# Altium schematic sheet preamp_v1r4.SchDoc
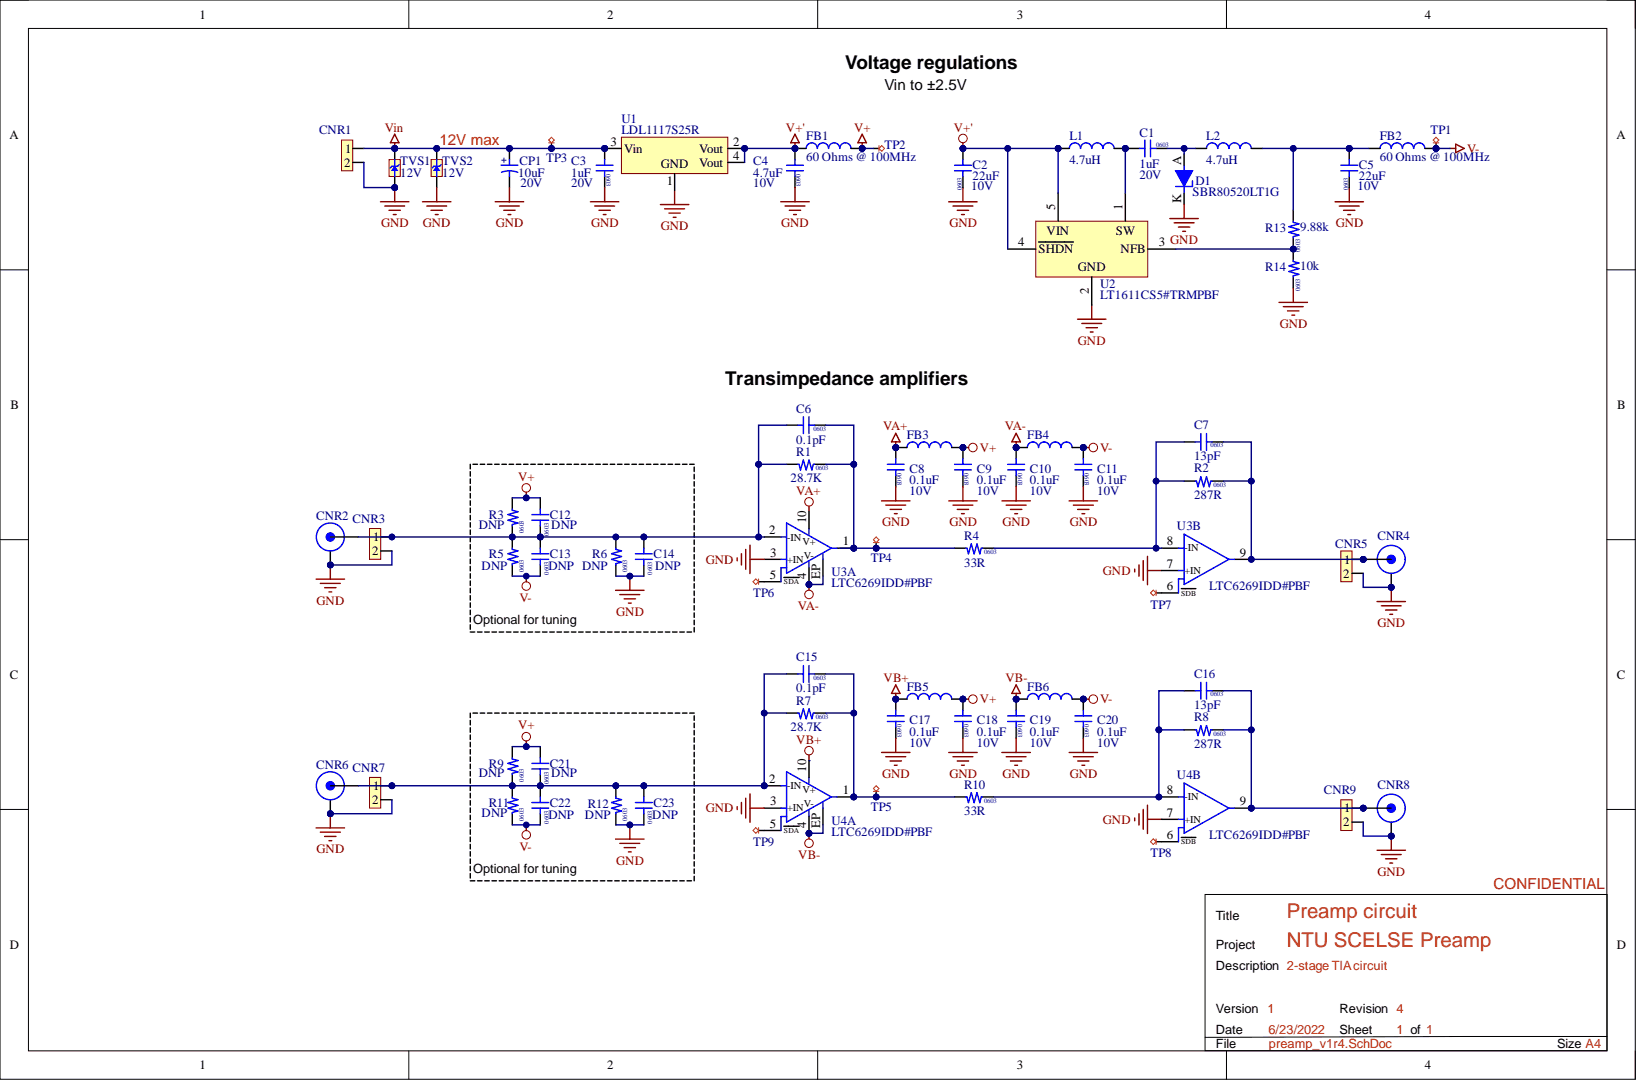
[diagram p0: preamp_v1r4.SchDoc]

## Parts
C1  value 1uF  PCB Footprint: 0603  [RES-0603]
C2  value 22uF  PCB Footprint: 0603  Rating: 10V  [CAP-0603]  (hidden comment: - CAP-NON)
C3  value 1uF  PCB Footprint: 0603  Rating: 20V  [RES-0603]
C4  value 4.7uF  PCB Footprint: 0603  Rating: 10V  [RES-0603]
C5  value 22uF  PCB Footprint: 0603  Rating: 10V  [CAP-0603]  (hidden comment: - CAP-NON)
C6  value 0.1pF  PCB Footprint: 0603  [CAP-0603]  (hidden comment: - CAP-NON)
C7  value 13pF  PCB Footprint: 0603  [CAP-0603]  (hidden comment: - CAP-NON)
C8  value 0.1uF  PCB Footprint: 0603  Rating: 10V  [RES-0603]
C9  value 0.1uF  PCB Footprint: 0603  Rating: 10V  [RES-0603]
C10  value 0.1uF  PCB Footprint: 0603  Rating: 10V  [RES-0603]
C11  value 0.1uF  PCB Footprint: 0603  Rating: 10V  [RES-0603]
C12  Cmmt: DNP  PCB Footprint: 0603  [CAP-0603]  (hidden comment: - CAP-NON)
C13  Cmmt: DNP  PCB Footprint: 0603  [CAP-0603]  (hidden comment: - CAP-NON)
C14  Cmmt: DNP  PCB Footprint: 0603  [CAP-0603]  (hidden comment: - CAP-NON)
C15  value 0.1pF  PCB Footprint: 0603  [CAP-0603]  (hidden comment: - CAP-NON)
C16  value 13pF  PCB Footprint: 0603  [CAP-0603]  (hidden comment: - CAP-NON)
C17  value 0.1uF  PCB Footprint: 0603  Rating: 10V  [RES-0603]
C18  value 0.1uF  PCB Footprint: 0603  Rating: 10V  [RES-0603]
C19  value 0.1uF  PCB Footprint: 0603  Rating: 10V  [RES-0603]
C20  value 0.1uF  PCB Footprint: 0603  Rating: 10V  [RES-0603]
C21  Cmmt: DNP  PCB Footprint: 0603  [CAP-0603]  (hidden comment: - CAP-NON)
C22  Cmmt: DNP  PCB Footprint: 0603  [CAP-0603]  (hidden comment: - CAP-NON)
C23  Cmmt: DNP  PCB Footprint: 0603  [CAP-0603]  (hidden comment: - CAP-NON)
CNR1  - CNR-IDC:2x1  [SIP02-2.54P]  (value not drawn)  (no BOM)
CNR2  SMA  [SMA_EDGE]  (value not drawn)
CNR3  - CNR-IDC:2x1  [SIP02-2.54P]  (value not drawn)  (no BOM)
CNR4  SMA  [SMA_EDGE]  (value not drawn)
CNR5  - CNR-IDC:2x1  [SIP02-2.54P]  (value not drawn)  (no BOM)
CNR6  SMA  [SMA_EDGE]  (value not drawn)
CNR7  - CNR-IDC:2x1  [SIP02-2.54P]  (value not drawn)  (no BOM)
CNR8  SMA  [SMA_EDGE]  (value not drawn)
CNR9  - CNR-IDC:2x1  [SIP02-2.54P]  (value not drawn)  (no BOM)
CP1  value 10uF  Rating: 20V  [SME8x10.5-2.2E [E]]  (hidden comment: - CAP-POL)
D1  Manufacturer Part No: SBR80520LT1G  [SOD123]  (hidden comment: - DIO-ZNR)
FB1  value 60 Ohms @ 100MHz  [IND-0603]  (hidden comment: Ferrite bead)
FB2  value 60 Ohms @ 100MHz  [IND-0603]  (hidden comment: Ferrite bead)
FB3  Ferrite bead  [IND-0603]  (value not drawn)
FB4  Ferrite bead  [IND-0603]  (value not drawn)
FB5  Ferrite bead  [IND-0603]  (value not drawn)
FB6  Ferrite bead  [IND-0603]  (value not drawn)
L1  value 4.7uH  [IND-0805[NL]]  (hidden comment: - IND)
L2  value 4.7uH  [IND-0805[NL]]  (hidden comment: - IND)
R1  value 28.7K  PCB Footprint: 0603  [RES-0603]  (hidden comment: - RES-FIX)
R2  value 287R  PCB Footprint: 0603  [RES-0603]  (hidden comment: - RES-FIX)
R3  Cmmt: DNP  PCB Footprint: 0603  [RES-0603]  (hidden comment: - RES-FIX)
R4  value 33R  PCB Footprint: 0603  [RES-0603]  (hidden comment: - RES-FIX)
R5  Cmmt: DNP  PCB Footprint: 0603  [RES-0603]  (hidden comment: - RES-FIX)
R6  Cmmt: DNP  PCB Footprint: 0603  [RES-0603]  (hidden comment: - RES-FIX)
R7  value 28.7K  PCB Footprint: 0603  [RES-0603]  (hidden comment: - RES-FIX)
R8  value 287R  PCB Footprint: 0603  [RES-0603]  (hidden comment: - RES-FIX)
R9  Cmmt: DNP  PCB Footprint: 0603  [RES-0603]  (hidden comment: - RES-FIX)
R10  value 33R  PCB Footprint: 0603  [RES-0603]  (hidden comment: - RES-FIX)
R11  Cmmt: DNP  PCB Footprint: 0603  [RES-0603]  (hidden comment: - RES-FIX)
R12  Cmmt: DNP  PCB Footprint: 0603  [RES-0603]  (hidden comment: - RES-FIX)
R13  value 9.88k  PCB Footprint: 0603  [RES-0603]  (hidden comment: - RES-FIX)
R14  value 10k  PCB Footprint: 0603  [RES-0603]  (hidden comment: - RES-FIX)
TP1  * Test Point  [TP-TH]  (value not drawn)  (no BOM)
TP2  * Test Point  [TP-TH]  (value not drawn)  (no BOM)
TP3  * Test Point  [TP-TH]  (value not drawn)  (no BOM)
TP4  * Test Point  [TP-TH]  (value not drawn)  (no BOM)
TP5  * Test Point  [TP-TH]  (value not drawn)  (no BOM)
TP6  * Test Point  [TP-SMD[NL]]  (value not drawn)  (no BOM)
TP7  * Test Point  [TP-SMD[NL]]  (value not drawn)  (no BOM)
TP8  * Test Point  [TP-SMD[NL]]  (value not drawn)  (no BOM)
TP9  * Test Point  [TP-SMD[NL]]  (value not drawn)  (no BOM)
TVS1  value 12V  [SOD923F]  (hidden comment: - TVS-UNI)
TVS2  value 12V  [SOD923F]  (hidden comment: - TVS-UNI)
U1  Manufacturer Part No: LDL1117S25R  [SOT223]  (hidden comment: > ICS: LDL1117SxxR)
U2  Manufacturer Part No: LT1611CS5#TRMPBF  [SOT95P280X145-5N]  (hidden comment: REG: LT1611CS5#TRMPBF)
U3  Manufacturer Part No: LTC6269IDD#PBF  [SON50P300X300X80-11N-D]  (hidden comment: > ICS: LTC6269IDD#PBF)  (parts A,B)
U4  Manufacturer Part No: LTC6269IDD#PBF  [SON50P300X300X80-11N-D]  (hidden comment: > ICS: LTC6269IDD#PBF)  (parts A,B)

## Nets
GND: C2.1 C3.1 C4.1 C5.1 C8.1 C9.1 C10.1 C11.1 C14.1 C17.1 C18.1 C19.1 C20.1 C23.1 CNR1.2 CNR2.2 CNR3.2 CNR4.2 CNR5.2 CNR6.2 CNR7.2 CNR8.2 CNR9.2 CP1.2 D1.K R6.1 R12.1 R14.1 TVS1.A TVS2.A U1.1 U2.2 U3.3(+IN) U3.7(+IN) U4.3(+IN) U4.7(+IN)
V+: C9.2 C12.2 C18.2 C21.2 FB1.2 FB3.2 FB5.2 R3.2 R9.2 TP2.TP
V+': C2.2 C4.2 FB1.1 L1.1 U1.2(Vout) U1.4(Vout) U2.4(SHDN) U2.5
V-: C11.2 C13.1 C20.2 C22.1 FB2.2 FB4.2 FB6.2 R5.1 R11.1 TP1.TP
VA+: C8.2 FB3.1 U3.10(V+)
VA-: C10.2 FB4.1 U3.4(V-) U3.EP
VB+: C17.2 FB5.1 U4.10(V+)
VB-: C19.2 FB6.1 U4.4(V-) U4.EP
Vin: C3.2 CNR1.1 CP1.1 TP3.TP TVS1.K TVS2.K U1.3(Vin)
NetC1_1: C1.1 D1.A L2.1
NetC1_2: C1.2 L1.2 U2.1(SW)
NetC5_2: C5.2 FB2.1 L2.2 R13.2
NetC6_1: C6.1 C12.1 C13.2 C14.2 CNR2.1 CNR3.1 R1.1 R3.1 R5.2 R6.2 U3.2(-IN)
NetC6_2: C6.2 R1.2 R4.1 TP4.TP U3.1
NetC7_1: C7.1 R2.1 R4.2 U3.8(-IN)
NetC7_2: C7.2 CNR4.1 CNR5.1 R2.2 U3.9
NetC15_1: C15.1 C21.1 C22.2 C23.2 CNR6.1 CNR7.1 R7.1 R9.1 R11.2 R12.2 U4.2(-IN)
NetC15_2: C15.2 R7.2 R10.1 TP5.TP U4.1
NetC16_1: C16.1 R8.1 R10.2 U4.8(-IN)
NetC16_2: C16.2 CNR8.1 CNR9.1 R8.2 U4.9
NetR13_1: R13.1 R14.2 U2.3(NFB)
NetTP6_TP: TP6.TP U3.5(SDA)
NetTP7_TP: TP7.TP U3.6(SDB)
NetTP8_TP: TP8.TP U4.6(SDB)
NetTP9_TP: TP9.TP U4.5(SDA)

## Library data of the parts
C1 = - CAP-NON: Manufacturer: Samsung Electro-Mechanics; Manufacturer Part No: CL10B105KA8NFNC; Cmmt: *New; Desc: SMT Chip Capacitor; Dielectric: X7S; Pads/Pins: 2; Rating: 20V; THT(y/n): N; Tolerance: 10%; Component Kind: Standard; Library Name: Libraries.IntLib
C2 = - CAP-NON: Manufacturer: Murata; Manufacturer Part No: GRM188R61A226ME15D; Cmmt: *New; Desc: SMT Chip Capacitor; Dielectric: X5R; Pads/Pins: 2; THT(y/n): N; Tolerance: 20%; Component Kind: Standard; Library Name: Libraries.IntLib
C3 = - CAP-NON: Manufacturer: Samsung Electro-Mechanics; Manufacturer Part No: CL10B105KA8NFNC; Desc: SMT Chip Capacitor; Dielectric: X7S; Pads/Pins: 2; THT(y/n): N; Tolerance: 10%; Cmmt: -; Component Kind: Standard; Library Name: Libraries.IntLib
C4 = - CAP-NON: Manufacturer: Murata; Manufacturer Part No: GRM188C81E475ME11D; Desc: SMT Chip Capacitor; Dielectric: X6S; Pads/Pins: 2; THT(y/n): N; Tolerance: 10%; Cmmt: -; Component Kind: Standard; Library Name: Libraries.IntLib
C5 = - CAP-NON: Manufacturer: Murata; Manufacturer Part No: GRM188R61A226ME15D; Desc: SMT Chip Capacitor; Dielectric: X5R; Pads/Pins: 2; THT(y/n): N; Tolerance: 20%; Cmmt: -; Component Kind: Standard; Library Name: Libraries.IntLib
C6 C15 = - CAP-NON: Manufacturer: Walsin; Manufacturer Part No: RF18N0R1B500CT; Desc: Multilayer Ceramic Capacitors MLCC - SMD/SMT; Pads/Pins: 2; Rating: 50V; THT(y/n): N; Tolerance: ±0.1pF; Cmmt: -; Component Kind: Standard; Dielectric: -; Library Name: Libraries.IntLib
C7 C16 = - CAP-NON: Manufacturer: Murata; Manufacturer Part No: GCM1885C2A130FA16J; Desc: Multilayer Ceramic Capacitors MLCC - SMD/SMT; Pads/Pins: 2; Rating: 100V; THT(y/n): N; Tolerance: ±1%; Cmmt: -; Component Kind: Standard; Dielectric: -; Library Name: Libraries.IntLib
C8 C10 C17 C19 = - CAP-NON: Manufacturer: Samsung Electro-Mechanics; Manufacturer Part No: CL10B104JB8NNNC; Desc: SMT Chip Capacitor; Dielectric: X7R; Pads/Pins: 2; THT(y/n): N; Tolerance: 5%; Cmmt: -; Component Kind: Standard; Library Name: Libraries.IntLib
C9 C11 C18 C20 = - CAP-NON: Manufacturer: Samsung Electro-Mechanics; Manufacturer Part No: CL10B104JB8NNNC; Cmmt: DNP; Desc: SMT Chip Capacitor; Dielectric: X7R; Pads/Pins: 2; THT(y/n): N; Tolerance: 5%; Component Kind: Standard; Library Name: Libraries.IntLib
C12-C14 C21-C23 = - CAP-NON: Desc: Multilayer Ceramic Capacitors MLCC - SMD/SMT; Pads/Pins: 2; Rating: 25V; THT(y/n): N; Tolerance: ±1%; Component Kind: Standard; Dielectric: -; Library Name: Libraries.IntLib
CNR2 CNR4 CNR6 CNR8 = SMA: Manufacturer: Taoglas; Manufacturer Part No: EMPCB.SMAFSTJ.C.HT; Component Kind: Standard; Library Name: ntu-scelse-preamp-2022.IntLib
CP1 = - CAP-POL: Manufacturer: United Chemi-Con; Manufacturer Part No: EMVH630ADA100MH63G; Pads/Pins: 2; THT(y/n): N; Tolerance: 20%; Cmmt: -; Component Kind: Standard; Library Name: Libraries.IntLib
D1 = - DIO-ZNR: Manufacturer: onsemi; Cmmt: *New; Desc: Schottky Diodes & Rectifiers REC SPCL SKTKY; Pads/Pins: 2; Rating: 0.5A; THT(y/n): N; Value: 20 V; Component Kind: Standard; Library Name: Libraries.IntLib; Tolerance: -
FB1 = - IND: Manufacturer: Murata; Manufacturer Part No: BLM18BB600SN1D; Desc: Ferrite Beads 60 OHM 25%; Pads/Pins: 2; Rating: 0.6A; THT(y/n): N; Tolerance: 25%; Value: 60 Ohms @ 100MHz; Cmmt: -; Component Kind: Standard; Library Name: Libraries.IntLib
FB2 = - IND: Manufacturer: Murata; Manufacturer Part No: BLM18BB600SN1D; Desc: Ferrite Beads 60 OHM 25%; Pads/Pins: 2; Rating: 0.6A; THT(y/n): N; Tolerance: 25%; Cmmt: -; Component Kind: Standard; Library Name: Libraries.IntLib
FB3-FB6 = - IND: Manufacturer: Vishay; Manufacturer Part No: CRCW06030000Z0EBC; Cmmt: Bypass 0R; Desc: Ferrite Beads 60 OHM 25%; Pads/Pins: 2; Rating: 0.6A; THT(y/n): N; Tolerance: 25%; Value: 0R; Component Kind: Standard; Library Name: Libraries.IntLib
L1 L2 = - IND: Manufacturer: Taiyo Yuden; Manufacturer Part No: BRC2012T4R7MD; Cmmt: *New; Desc: Fixed Inductors 0805 4.7uH .265Ohms +/-20%Tol 700mA; Pads/Pins: 2; Rating: 500mA; THT(y/n): N; Tolerance: 20%; Component Kind: Standard; Library Name: Libraries.IntLib
R1 R7 = - RES-FIX: Manufacturer: Bourns; Manufacturer Part No: CR0603-FX-2872ELF; Pads/Pins: 2; Rating: 50V; THT(y/n): N; Tolerance: ±1%; Cmmt: -; Component Kind: Standard; Desc: -; Library Name: Libraries.IntLib
R2 R8 = - RES-FIX: Manufacturer: Yageo; Manufacturer Part No: AC0603FR-07287RL; Pads/Pins: 2; Rating: 50V; THT(y/n): N; Tolerance: ±1%; Cmmt: -; Component Kind: Standard; Desc: -; Library Name: Libraries.IntLib
R3 R5 R6 R9 R11 R12 = - RES-FIX: Pads/Pins: 2; Rating: 50V; THT(y/n): N; Tolerance: ±1%; Component Kind: Standard; Desc: -; Library Name: Libraries.IntLib
R4 R10 = - RES-FIX: Manufacturer: Yageo; Manufacturer Part No: RC0603FR-0733RL; Pads/Pins: 2; Rating: 50V; THT(y/n): N; Tolerance: ±1%; Cmmt: -; Component Kind: Standard; Desc: -; Library Name: Libraries.IntLib
R13 = - RES-FIX: Manufacturer: KOA Speer; Manufacturer Part No: RN73H1JTTD9881F100; Cmmt: *New; Desc: Thin Film Resistors 9.88kOhm,0603,1%,10p pm,100mW,75V; Pads/Pins: 2; Rating: 50V; THT(y/n): N; Tolerance: ±1%; Component Kind: Standard; Library Name: Libraries.IntLib
R14 = - RES-FIX: Manufacturer: YAGEO; Manufacturer Part No: RT0603DRE0710KL; Cmmt: *New; Pads/Pins: 2; Rating: 50V; THT(y/n): N; Tolerance: ±1%; Component Kind: Standard; Desc: -; Library Name: Libraries.IntLib
TP1-TP9 = * Test Point: Desc: Test Point for Evaluate Propose; Cmmt: -; Library Name: Libraries.IntLib
TVS1 TVS2 = - TVS-UNI: Manufacturer: onsemi; Manufacturer Part No: ESD9X12ST5G; Desc: ESD Suppressors / TVS Diodes SOD-923 ESD PROT; Pads/Pins: 2; Rating: 140W; THT(y/n): N; Cmmt: -; Component Kind: Standard; Library Name: Libraries.IntLib; Tolerance: -
U1 = > ICS: LDL1117SxxR: Manufacturer: STMicroelectronics; Desc: LDO Voltage Regulators 1.2 A High PSRR low dropout linear voltage regulator; Pads/Pins: 4; THT(y/n): N; Cmmt: -; Component Kind: Standard; Rating: -; Tolerance: -; Value: -; Library Name: ntu-scelse-preamp-2022.IntLib
U2 = REG: LT1611CS5#TRMPBF: Integrated Circuit; Manufacturer: Analog Devices; Cmmt: *New; Pads/Pins: 5; THT(y/n): N; Component Kind: Standard; Desc: -; Rating: -; Tolerance: -; Value: -; Library Name: ntu-scelse-preamp-2022.IntLib
U3 U4 = > ICS: LTC6269IDD#PBF: Manufacturer: AD; Desc: 500MHz Ultra-Low Bias Current FET Input Op Amp; Pads/Pins: 11; THT(y/n): N; Cmmt: -; Component Kind: Standard; Rating: -; Tolerance: -; Value: -; Library Name: ntu-scelse-preamp-2022.IntLib
CNR1 CNR3 CNR5 CNR7 CNR9 = - CNR-IDC:2x1: Cmmt: -; Library Name: Libraries.IntLib
